# Altium schematic sheet Sheet1.SchDoc(Sheet1)
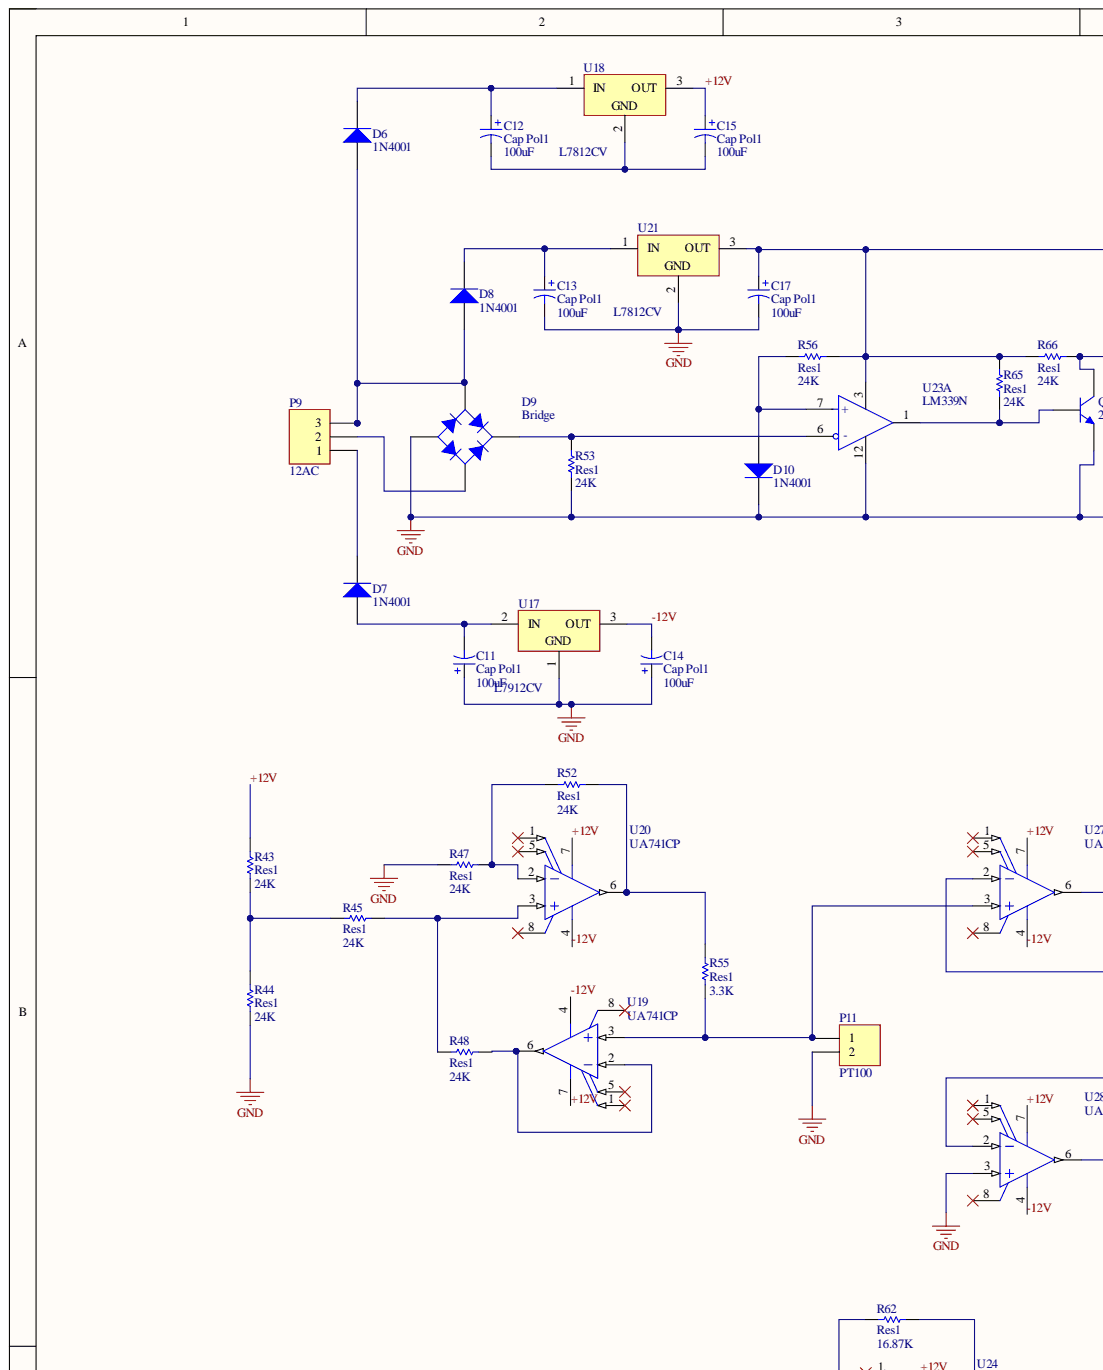
[diagram p0: Sheet1.SchDoc, top left]
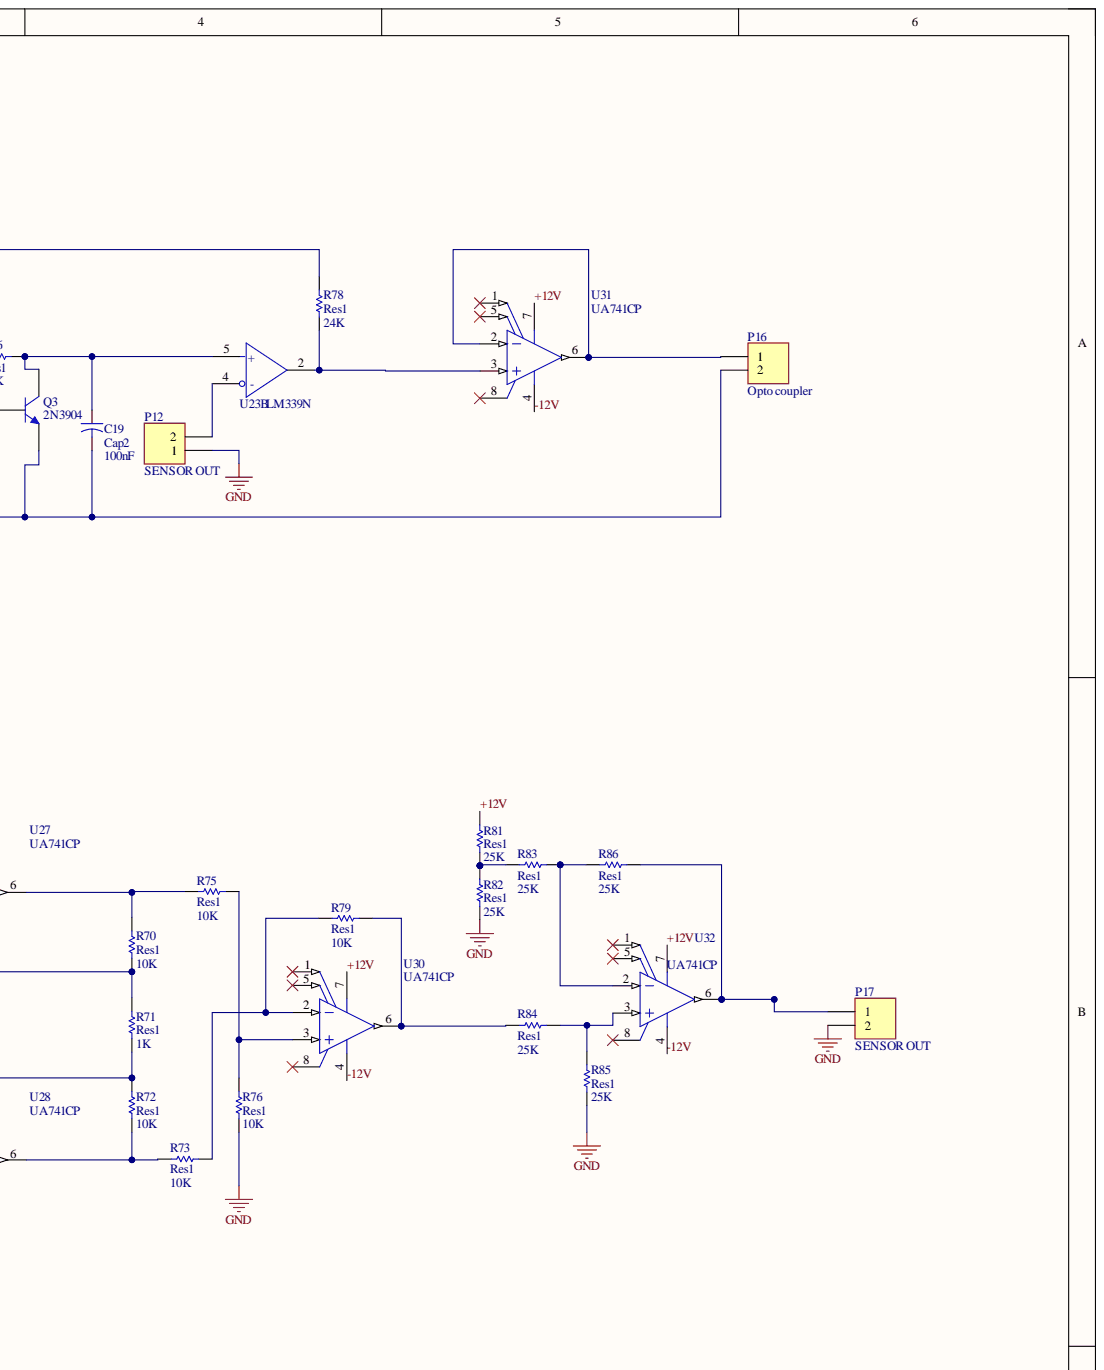
[diagram p1: Sheet1.SchDoc, top right]
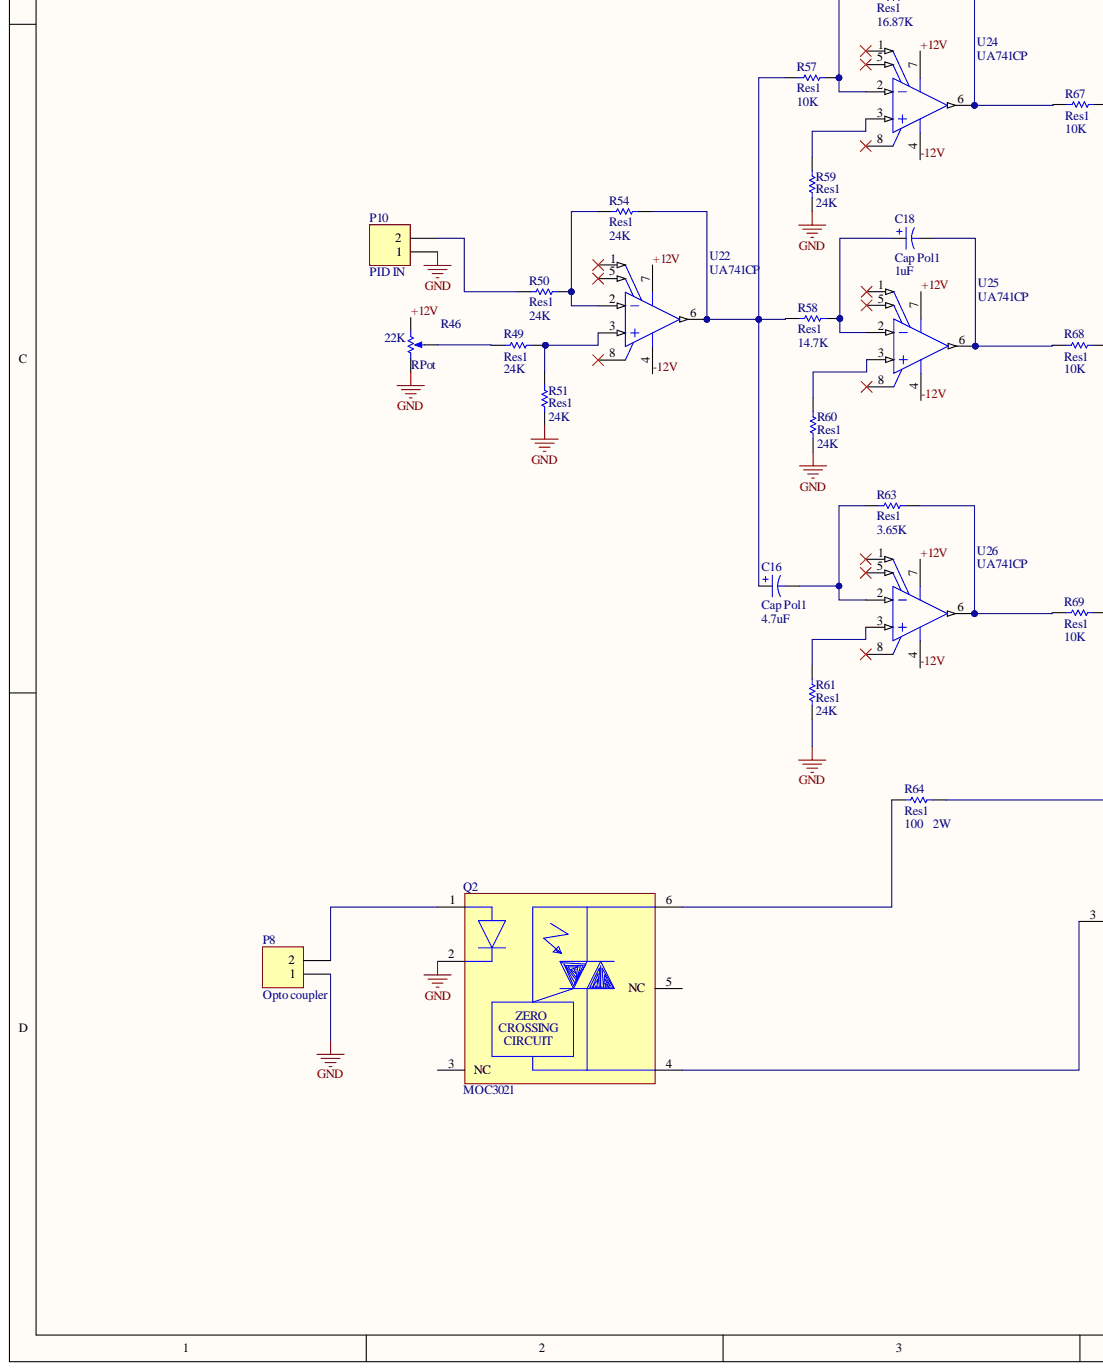
[diagram p2: Sheet1.SchDoc, bottom left]
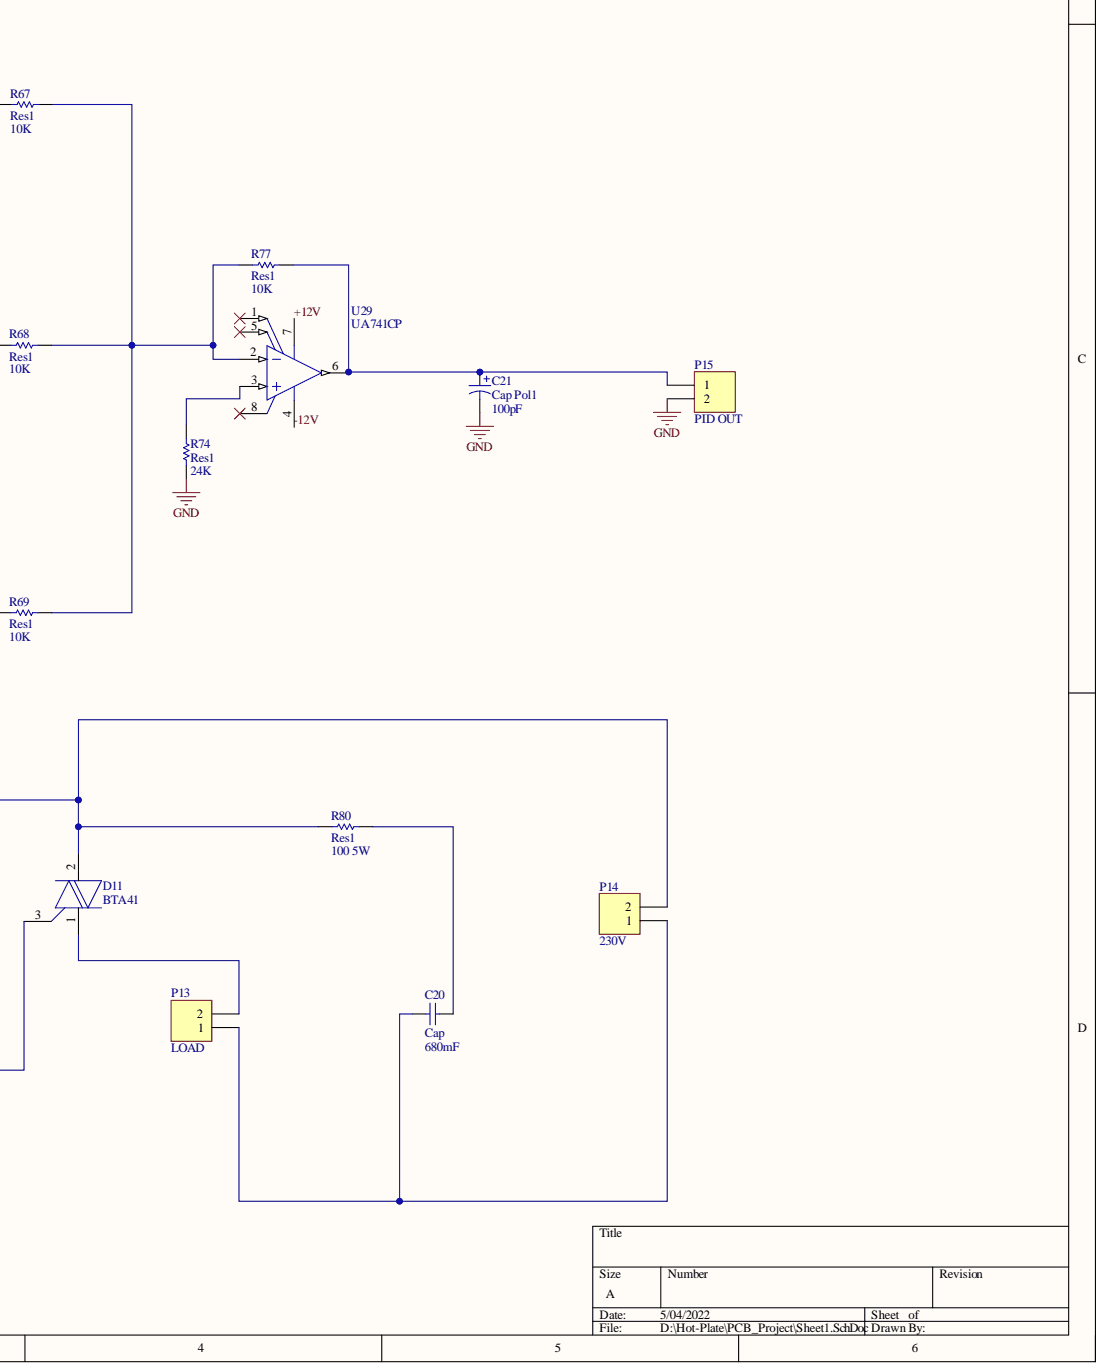
[diagram p3: Sheet1.SchDoc, bottom right]

## Parts
C11  Cap Pol1  value 100uF  [RB7.6-15]
C12  Cap Pol1  value 100uF  [RB7.6-15]
C13  Cap Pol1  value 100uF  [RB7.6-15]
C14  Cap Pol1  value 100uF  [RB7.6-15]
C15  Cap Pol1  value 100uF  [RB7.6-15]
C16  Cap Pol1  value 4.7uF  [RB7.6-15]
C17  Cap Pol1  value 100uF  [RB7.6-15]
C18  Cap Pol1  value 1uF  [RB7.6-15]
C19  Cap2  value 100nF  [CAPR5-4X5]
C20  Cap  value 680mF  [RAD-0.3]
C21  Cap Pol1  value 100pF  [RB7.6-15]
D6  1N4001  [DO-41]
D7  1N4001  [DO-41]
D8  1N4001  [DO-41]
D9  Bridge  [D-38]
D10  1N4001  [DO-41]
D11  BTA41  [FP-TO-220AB-MFG]
P8  Opto coupler  [HDR1X2]
P9  12AC  [HDR1X3]
P10  PID IN  [HDR1X2]  (value not drawn)
P11  PT100  [HDR1X2]
P12  SENSOR OUT  [HDR1X2]
P13  LOAD  [HDR1X2]
P14  230V  [HDR1X2]
P15  PID OUT  [HDR1X2]  (value not drawn)
P16  Opto coupler  [HDR1X2]
P17  SENSOR OUT  [HDR1X2]
Q2  MOC3021  [FP-646BX-MFG]
Q3  2N3904  [ONSC-TO-92-3-29-11]
R43  Res1  value 24K  [AXIAL-0.3]
R44  Res1  value 24K  [AXIAL-0.3]
R45  Res1  value 24K  [AXIAL-0.3]
R46  RPot  value 22K  [VR5]
R47  Res1  value 24K  [AXIAL-0.3]
R48  Res1  value 24K  [AXIAL-0.3]
R49  Res1  value 24K  [AXIAL-0.3]
R50  Res1  value 24K  [AXIAL-0.3]
R51  Res1  value 24K  [AXIAL-0.3]
R52  Res1  value 24K  [AXIAL-0.3]
R53  Res1  value 24K  [AXIAL-0.3]
R54  Res1  value 24K  [AXIAL-0.3]
R55  Res1  value 3.3K  [AXIAL-0.3]
R56  Res1  value 24K  [AXIAL-0.3]
R57  Res1  value 10K  [AXIAL-0.3]
R58  Res1  value 14.7K  [AXIAL-0.3]
R59  Res1  value 24K  [AXIAL-0.3]
R60  Res1  value 24K  [AXIAL-0.3]
R61  Res1  value 24K  [AXIAL-0.3]
R62  Res1  value 16.87K  [AXIAL-0.3]
R63  Res1  value 3.65K  [AXIAL-0.3]
R64  Res1  value 100   2W  [AXIAL-0.3]
R65  Res1  value 24K  [AXIAL-0.3]
R66  Res1  value 24K  [AXIAL-0.3]
R67  Res1  value 10K  [AXIAL-0.3]
R68  Res1  value 10K  [AXIAL-0.3]
R69  Res1  value 10K  [AXIAL-0.3]
R70  Res1  value 10K  [AXIAL-0.3]
R71  Res1  value 1K  [AXIAL-0.3]
R72  Res1  value 10K  [AXIAL-0.3]
R73  Res1  value 10K  [AXIAL-0.3]
R74  Res1  value 24K  [AXIAL-0.3]
R75  Res1  value 10K  [AXIAL-0.3]
R76  Res1  value 10K  [AXIAL-0.3]
R77  Res1  value 10K  [AXIAL-0.3]
R78  Res1  value 24K  [AXIAL-0.3]
R79  Res1  value 10K  [AXIAL-0.3]
R80  Res1  value 100 5W  [AXIAL-0.3]
R81  Res1  value 25K  [AXIAL-0.3]
R82  Res1  value 25K  [AXIAL-0.3]
R83  Res1  value 25K  [AXIAL-0.3]
R84  Res1  value 25K  [AXIAL-0.3]
R85  Res1  value 25K  [AXIAL-0.3]
R86  Res1  value 25K  [AXIAL-0.3]
U17  L7912CV  [TO-220]
U18  L7812CV  [TO220]
U19  UA741CP  [P0008A]
U20  UA741CP  [P0008A]
U21  L7812CV  [TO220]
U22  UA741CP  [P0008A]
U23  LM339N  [FP-N0014A-MFG]  (parts A,B)
U24  UA741CP  [P0008A]
U25  UA741CP  [P0008A]
U26  UA741CP  [P0008A]
U27  UA741CP  [P0008A]
U28  UA741CP  [P0008A]
U29  UA741CP  [P0008A]
U30  UA741CP  [P0008A]
U31  UA741CP  [P0008A]
U32  UA741CP  [P0008A]

## Nets
GND: C11.1 C13.2 C14.1 C17.2 C19.2 C21.2 D9.3 D10.2 P8.1 P10.1 P11.2 P12.1 P15.2 P16.2 P17.2 Q2.2 Q3.1 R44.1 R46.1 R47.2 R51.2 R53.1 R59.2 R60.2 R61.2 R74.2 R76.2 R82.1 R85.2 U17.1 U21.2 U23.12 U28.3
+12V: C15.1 R43.2 R46.2 R81.2 U18.3 U19.7 U20.7 U22.7 U24.7 U25.7 U26.7 U27.7 U28.7 U29.7 U30.7 U31.7 U32.7
-12V: C14.2 U17.3 U19.4 U20.4 U22.4 U24.4 U25.4 U26.4 U27.4 U28.4 U29.4 U30.4 U31.4 U32.4
NetC11_2: C11.2 D7.1 U17.2
NetC12_1: C12.1 D6.2 U18.1
NetC12_2: C12.2 C15.2 U18.2
NetC13_1: C13.1 D8.2 U21.1
NetC16_1: C16.1 R54.1 R57.2 R58.2 U22.6
NetC16_2: C16.2 R63.2 U26.2
NetC17_1: C17.1 R56.1 R65.1 R66.1 R78.2 U21.3 U23.3
NetC18_1: C18.1 R58.1 U25.2
NetC18_2: C18.2 R68.2 U25.6
NetC19_1: C19.1 Q3.3 R66.2 U23.5(+)
NetC20_1: C20.1 P13.1 P14.1
NetC20_2: C20.2 R80.2
NetC21_1: C21.1 P15.1 R77.1 U29.6
NetD6_1: D6.1 D8.1 D9.4 P9.3
NetD7_2: D7.2 P9.1
NetD9_1: D9.1 R53.2 U23.6(-)
NetD9_2: D9.2 P9.2
NetD10_1: D10.1 R56.2 U23.7(+)
NetD11_1: D11.1 P13.2
NetD11_2: D11.2 P14.2 R64.2 R80.1
NetD11_3: D11.3 Q2.4
NetP8_2: P8.2 Q2.1
NetP10_2: P10.2 R50.2
NetP11_1: P11.1 R55.2 U19.3 U27.3
NetP12_2: P12.2 U23.4(-)
NetP16_1: P16.1 U31.2 U31.6
NetP17_1: P17.1 R86.2 U32.6
NetQ2_6: Q2.6 R64.1
NetQ3_2: Q3.2 R65.2 U23.1
NetR43_1: R43.1 R44.2 R45.2
NetR45_1: R45.1 R48.2 U20.3
NetR46_3: R46.3 R49.2
NetR47_1: R47.1 R52.2 U20.2
NetR48_1: R48.1 U19.2 U19.6
NetR49_1: R49.1 R51.1 U22.3
NetR50_1: R50.1 R54.2 U22.2
NetR52_1: R52.1 R55.1 U20.6
NetR57_1: R57.1 R62.2 U24.2
NetR59_1: R59.1 U24.3
NetR60_1: R60.1 U25.3
NetR61_1: R61.1 U26.3
NetR62_1: R62.1 R67.2 U24.6
NetR63_1: R63.1 R69.2 U26.6
NetR67_1: R67.1 R68.1 R69.1 R77.2 U29.2
NetR70_1: R70.1 R75.1 U27.6
NetR70_2: R70.2 R71.1 U27.2
NetR71_2: R71.2 R72.1 U28.2
NetR72_2: R72.2 R73.1 U28.6
NetR73_2: R73.2 R79.1 U30.2
NetR74_1: R74.1 U29.3
NetR75_2: R75.2 R76.1 U30.3
NetR78_1: R78.1 U23.2 U31.3
NetR79_2: R79.2 R84.1 U30.6
NetR81_1: R81.1 R82.2 R83.1
NetR83_2: R83.2 R86.1 U32.2
NetR84_2: R84.2 R85.1 U32.3

Not connected: Q2 3(NC) 5(NC); U19 1 5 8; U20 1 5 8; U22 1(+12V) 5 8; U24 1 5 8; U25 1 5 8; U26 1 5 8; U27 1 5 8; U28 1 5 8; U29 1 5 8; U30 1 5 8; U31 1 5 8; U32 1 5 8

## Library data of the parts
C11-C18 C21 = Cap Pol1: Polarized Capacitor (Radial); Component Kind: Standard; LatestRevisionDate: 17-Jul-2002; LatestRevisionNote: Re-released for DXP Platform.; Library Name: Miscellaneous Devices.IntLib; PackageDescription: Polarized Capacitor (Radial); 2 Leads; PackageReference: RB7.6-15; Published: 8-Jun-2000; Signal Integrity: Cap Pol; Simulation: CAP; Publisher: Altium Limited
C19 = Cap2: Capacitor; Component Kind: Standard; Library Name: Miscellaneous Devices.IntLib; PackageDescription: Capacitor; 2 Leads; PackageReference: CAPR5-4X5; Published: 23-Sep-2002; Signal Integrity: Cap; Simulation: CAP; Publisher: Altium Limited
C20 = Cap: Capacitor; Component Kind: Standard; LatestRevisionDate: 17-Jul-2002; LatestRevisionNote: Re-released for DXP Platform.; Library Name: Miscellaneous Devices.IntLib; PackageDescription: Radial Cap, Thru-Hole; 2 Leads; 0.3 in Pin Spacing; PackageReference: RAD-0.3; Published: 8-Jun-2000; Signal Integrity: Cap; Simulation: CAP; Publisher: Altium Limited
D6-D8 D10 = Diode 1N4001: 1 Amp General Purpose Rectifier; Code_JEDEC: DO-204-AL; Component Kind: Standard; ComponentLink1Description: Manufacturer Link; ComponentLink1URL: http://www.fairchildsemi.com/; ComponentLink2Description: Datasheet; ComponentLink2URL: http://www.fairchildsemi.com/ds/1N%2F1N4001.pdf; DatasheetVersion: 1998; LatestRevisionDate: 17-Jul-2002; LatestRevisionNote: Re-released for DXP Platform.; Library Name: Miscellaneous Devices.IntLib; PackageDescription: Diode, Axial; Body 5.2 x 2.7 mm (LxDia. max), Lead Dia. 0.86 mm (max); PackageReference: DO-41; PackageVersion: Aug-1999; Published: 8-Jun-2000; Signal Integrity: 1N4001; Simulation: 1N4001; Publisher: Altium Limited
D9 = Bridge1: Full Wave Diode Bridge; Component Kind: Standard; DatasheetVersion: E2732B; LatestRevisionDate: 29-May-2009; LatestRevisionNote: Sch pins A & K swapped.; Library Name: Miscellaneous Devices.IntLib; PackageDescription: Bridge Rectifier; 4 Terminals; Body 9.4 x 9.4 mm (typ); Pitch 5.08 mm; PackageReference: D-38; Published: 8-Jun-2000; Simulation: BRIDGE; Publisher: Altium Limited
D11 = CMP-12193-000008-1: TRIAC ALTERNISTOR 600V TO220AB; Manufacturer: STMicroelectronics; Manufacturer Part Number: BTA24-600BWRG; Forward Voltage: 1.55V; Gate Trigger Current: 50mA; Gate Trigger Voltage: 1.3V; Hold Current: 75mA; Max Junction Temp: +125°C; On State Current Rms: 25A; Standoff Height: 3.75mm; Automotive: No; Category: Diode; Component Kind: Standard; ComponentLink2Description: Datasheet; ComponentLink2URL: https://www.st.com/resource/en/datasheet/bta24.pdf; Device Class L1: Discrete Semiconductors; Device Class L2: Thyristors; Device Class L3: TRIACs; Digikey Description: TRIAC ALTERNISTOR 600V TO220AB; Height: 19.65mm; Lead Free: Yes; Library Name: Altium Content Vault; Mouser Description: Triacs 25 Amp 600 Volt; Octopart: https://octopart.com/bta24-600bwrg-stmicroelectronics-360258; Off State Voltage: 600V; Package: TO-220AB; RoHS: TRUE; Temperature: -40°C to +125°C; Temperature Range High: +125°C; Temperature Range Low: -40°C
P8 P10-P17 = Header 2: Header, 2-Pin; Component Kind: Standard; LatestRevisionDate: 17-Jul-2002; LatestRevisionNote: Re-released for DXP Platform.; Library Name: Miscellaneous Connectors.IntLib; Signal Integrity: Connector; Publisher: Altium Limited
P9 = Header 3: Header, 3-Pin; Component Kind: Standard; LatestRevisionDate: 17-Jul-2002; LatestRevisionNote: Re-released for DXP Platform.; Library Name: Miscellaneous Connectors.IntLib; Signal Integrity: Connector; Publisher: Altium Limited
Q2 = CMP-07144-000005-1: OPTOISOLATOR 4.17KV TRIAC 6DIP; Manufacturer: ON Semiconductor; Manufacturer Part Number: MOC3041M; Forward Current: 30mA; Forward Voltage: 1.25V; Isolation Voltage: 4170Vrms; Max Junction Temp: +100°C; Power Dissipation: 250mW; Reverse Voltage: 6V; Standoff Height: 0.38mm; Automotive: No; Category: Trans; Component Kind: Standard; ComponentLink2Description: Datasheet; ComponentLink2URL: https://www.onsemi.com/pub/Collateral/MOC3043M-D.pdf; Device Class L1: Optoelectronics; Device Class L2: Optocouplers; Device Class L3: unset; Digikey Description: OPTOISOLATOR 4.17KV TRIAC 6DIP; Footprint Url: https://www.onsemi.com/pub/Collateral/646BX.PDF; Height: 5.08mm; Lead Free: Yes; Library Name: Altium Content Vault; Mouser Description: Optocoupler Triac AC Output 1 Channel 400VDRM 6-Pin Plastic Dip White Bulk; Octopart: https://octopart.com/moc3041m-on+semiconductor-84330395; Package: 646BX; RoHS: TRUE; Temperature: -40°C to +85°C; Temperature Range High: +85°C; Temperature Range Low: -40°C
Q3 = NPN: NPN Bipolar Transistor; Code_JEDEC: TO-226-AA; Component Kind: Standard; LatestRevisionDate: 17-Jul-2002; LatestRevisionNote: Re-released for DXP Platform.; Library Name: Miscellaneous Devices.IntLib; PackageDescription: TO, Flat Index; 3 In-Line, Axial Leads; Body Dia. 4.8mm; Leads 0.55 x 0.51 mm (max); PackageReference: TO-226-AA; Published: 8-Jun-2000; Signal Integrity: NPN; Simulation: NPN; Publisher: Altium Limited
R43-R45 R47-R55 R57-R65 R67-R86 = Res1: Resistor; Component Kind: Standard; LatestRevisionDate: 17-Jul-2002; LatestRevisionNote: Re-released for DXP Platform.; Library Name: Miscellaneous Devices.IntLib; PackageDescription: Axial Device, Thru-Hole; 2 Leads; 0.3 in Pin Spacing; PackageReference: AXIAL-0.3; Published: 8-Jun-2000; Signal Integrity: Res; Simulation: RESISTOR; Publisher: Altium Limited
R46 = RPot: Potentiometer; Set Position: 0.5; Sim Note: Enter the Resistance value and the set point into parameter fields.; Component Kind: Standard; LatestRevisionDate: 17-Jul-2002; LatestRevisionNote: Re-released for DXP Platform.; Library Name: Miscellaneous Devices.IntLib; PackageDescription: Variable Resistor, Thru-Hole; 3 Leads; PackageReference: VR5; Published: 8-Jun-2000; Simulation: POT; Publisher: Altium Limited
R56 R66 = Res1: Resistor; Value: 24K; Component Kind: Standard; LatestRevisionDate: 17-Jul-2002; LatestRevisionNote: Re-released for DXP Platform.; Library Name: Miscellaneous Devices.IntLib; PackageDescription: Axial Device, Thru-Hole; 2 Leads; 0.3 in Pin Spacing; PackageReference: AXIAL-0.3; Published: 8-Jun-2000; Signal Integrity: Res; Simulation: RESISTOR; Publisher: Altium Limited
U17 = CMP-0244-00457-1: Negative Voltage Regulator, -12V, 3-Pin TO-220; Component Kind: Standard; ComponentLink1Description: Manufacturer URL; ComponentLink1URL: http://www.st.com; ComponentLink2Description: Datasheet; ComponentLink2URL: http://www.st.com/internet/com/TECHNICAL_RESOURCES/TECHNICAL_LITERATURE/DATASHEET/CD00000450.pdf; DatasheetVersion: 1/11/2011; Library Name: Altium Content Vault; PackageDescription: TO, Thru-Hole, Vertical, Heatsink Mounted; 3 In-Line Leads; Pitch 2.54 mm; PackageReference: TO-220; PackageVersion: 2011
U18 U21 = CMP-0244-00450-1: Positive Voltage Regulator, 12V, 3-Pin TO-220; Component Kind: Standard; ComponentLink1Description: Manufacturer URL; ComponentLink1URL: http://www.st.com; ComponentLink2Description: Datasheet; ComponentLink2URL: http://www.st.com/internet/com/TECHNICAL_RESOURCES/TECHNICAL_LITERATURE/DATASHEET/CD00000444.pdf; ComponentLink3Description: Package Specification; ComponentLink3URL: http://www.st.com/internet/com/TECHNICAL_RESOURCES/TECHNICAL_LITERATURE/PACKAGE_INFORMATION/CD00004649.pdf; DatasheetVersion: 16/11/2011; Library Name: Altium Content Vault; PackageDescription: TO, Thru-Hole, Vertical, Heatsink Mounted; 3 In-Line Leads; Pitch 2.54 mm; PackageReference: TO220; PackageVersion: ver3.0
U19 U20 U22 U24-U32 = CMP-0017-00973-2: General-Purpose Operational Amplifier, 7 to 36 V, 0 to 70 degC, 8-pin DIP (P8), Pb-Free (RoHS); Manufacturer: Texas Instruments; CMRR(Min)(dB): 70; GBW(Typ)(MHz): 1; IIB(Max)(pA): 800000; Iq per channel(Max)(mA): 2.8; Slew Rate(Typ)(V/us): 0.5; Sub Family: General Purpose Amplifier, High Supply Voltage Amplifier (>=30V); Total Supply Voltage(Max)(+5V is 5, +/-5V is 10): 36; Total Supply Voltage(Min)(+5V is 5, +/-5V is 10): 7; Vio (25C)(Max)(mV): 6; Code_JEDEC: MS-001; Component Kind: Standard; ComponentLink1Description: Manufacturer URL; ComponentLink1URL: http://www.ti.com/; ComponentLink2Description: Datasheet; ComponentLink2URL: http://www.ti.com/lit/ds/symlink/ua741.pdf; ComponentLink3Description: Package Specification; ComponentLink3URL: http://www.ti.com/litv/pdf/mpdi001b; DatasheetVersion: SLOS094B; Eco-Plan: Pb-Free (RoHS); Library Name: Altium Content Vault; Mounting Technology: Through Hole; Number of Channels: 1; PackageDescription: 8-Pin Plastic In-Line, Body 9.59 x 6.35 mm, Pitch 2.54 mm; PackageReference: P0008A; PackageVersion: 4040082/E, 04/2010; PartNumber: UA741CP
U23 = CMP-0055-00143-4: IC QUAD DIFF COMPARATOR 14-DIP; Dual Supply Voltage: 9V; Input Bias Current: 250nA; Input Offset Voltage (Vos): 5mV; Max Dual Supply Voltage: 15V; Max Power Dissipation: 1.05W; Max Supply Current: 500uA; Max Supply Voltage: 30V; Min Dual Supply Voltage: 1V; Min Supply Voltage: 2V; Nominal Supply Current: 2mA; Number of Channels: 4; Output Current: 20mA; Output Current per Channel: 6mA; Output Type: TTL; Power Dissipation: 1.05kW; Quiescent Current: 2.5mA; Response Time: 1.3us; Voltage Gain: 106.02dB; Case/Package: PDIP; Component Kind: Standard; Contact Plating: Gold; Height: 4.57mm; Lead Free: Lead Free; Length: 19.3mm; Library Name: Altium Content Vault; Max Input Current: 250nA; Max Operating Temperature: 70°C; Min Operating Temperature: 0°C; Mount: Through Hole; Number of Elements: 4; Number of Pins: 14; Package Quantity: 25; Packaging: Rail/Tube; Radiation Hardening: No; REACH SVHC: No SVHC; Weight: 0.032734oz; Width: 6.35mm
